# Altium schematic sheet power_board.SchDoc
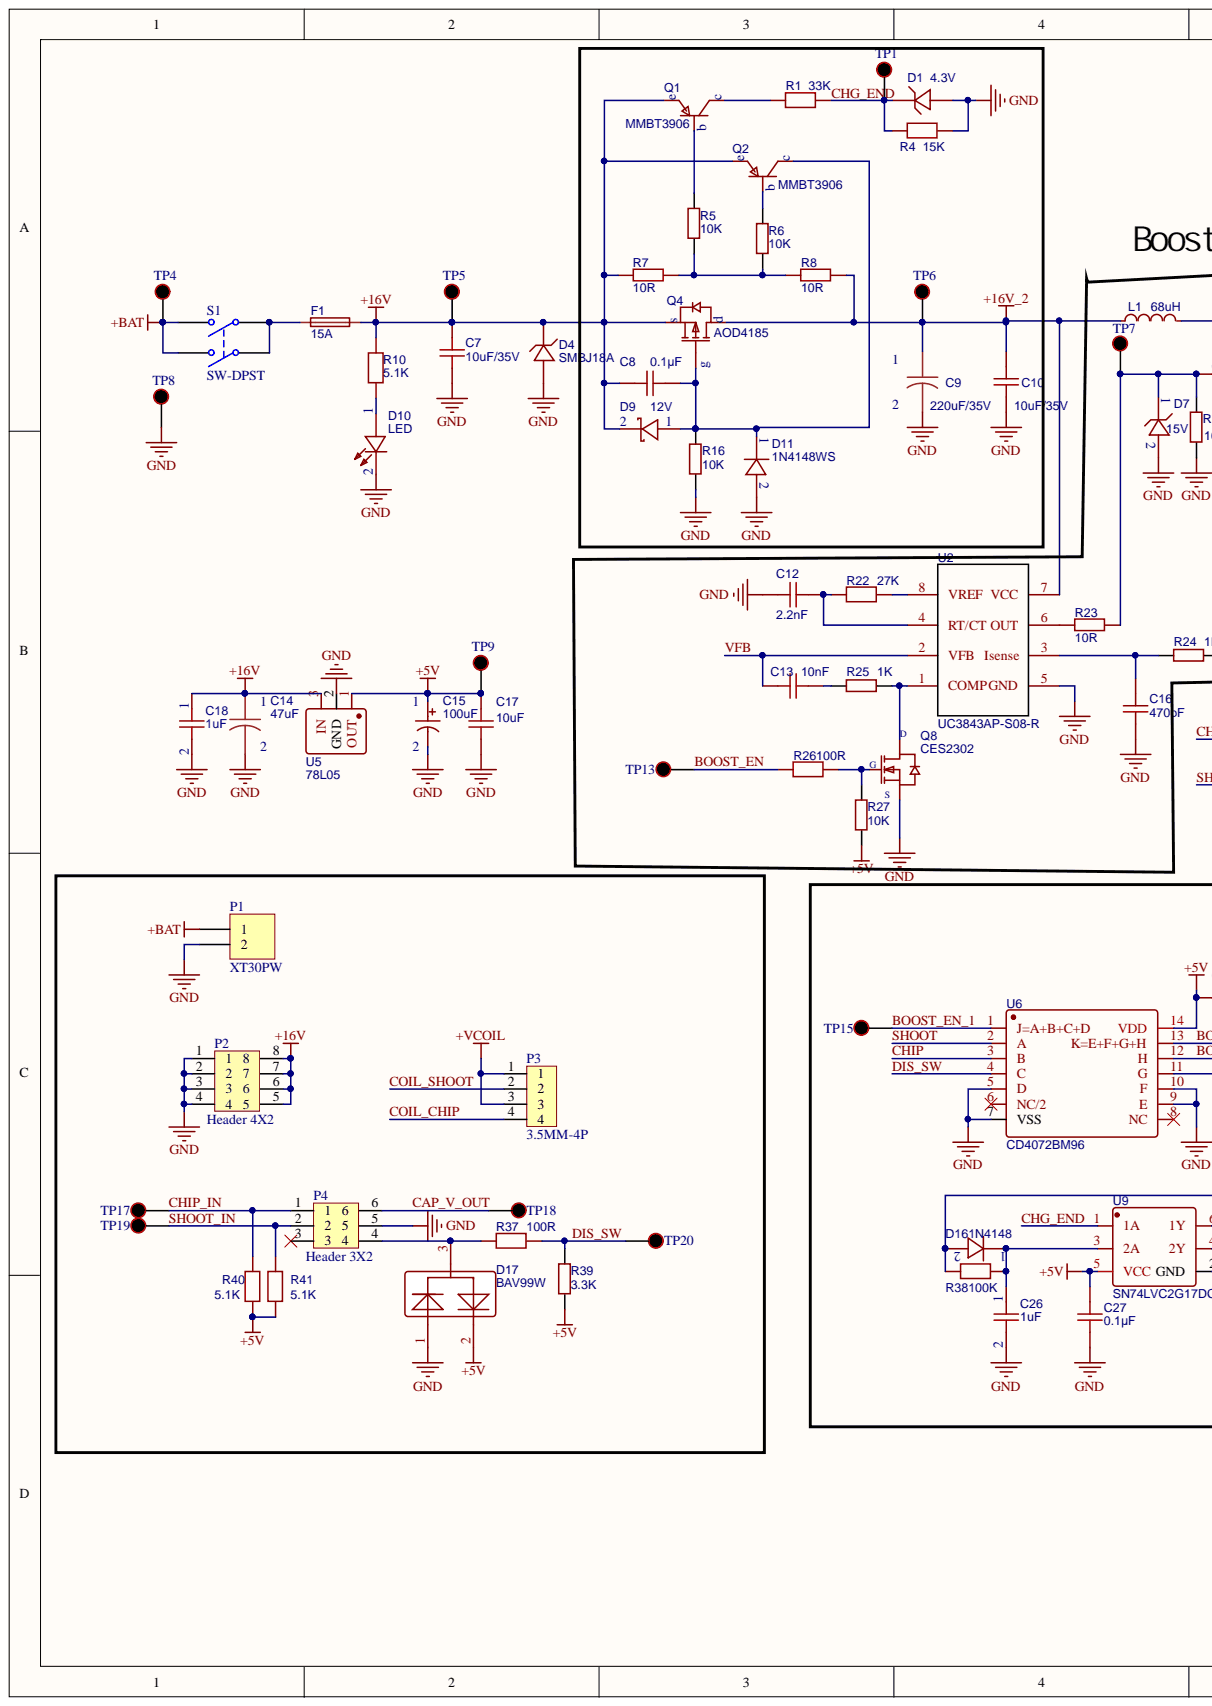
[diagram p0: power_board.SchDoc, left]
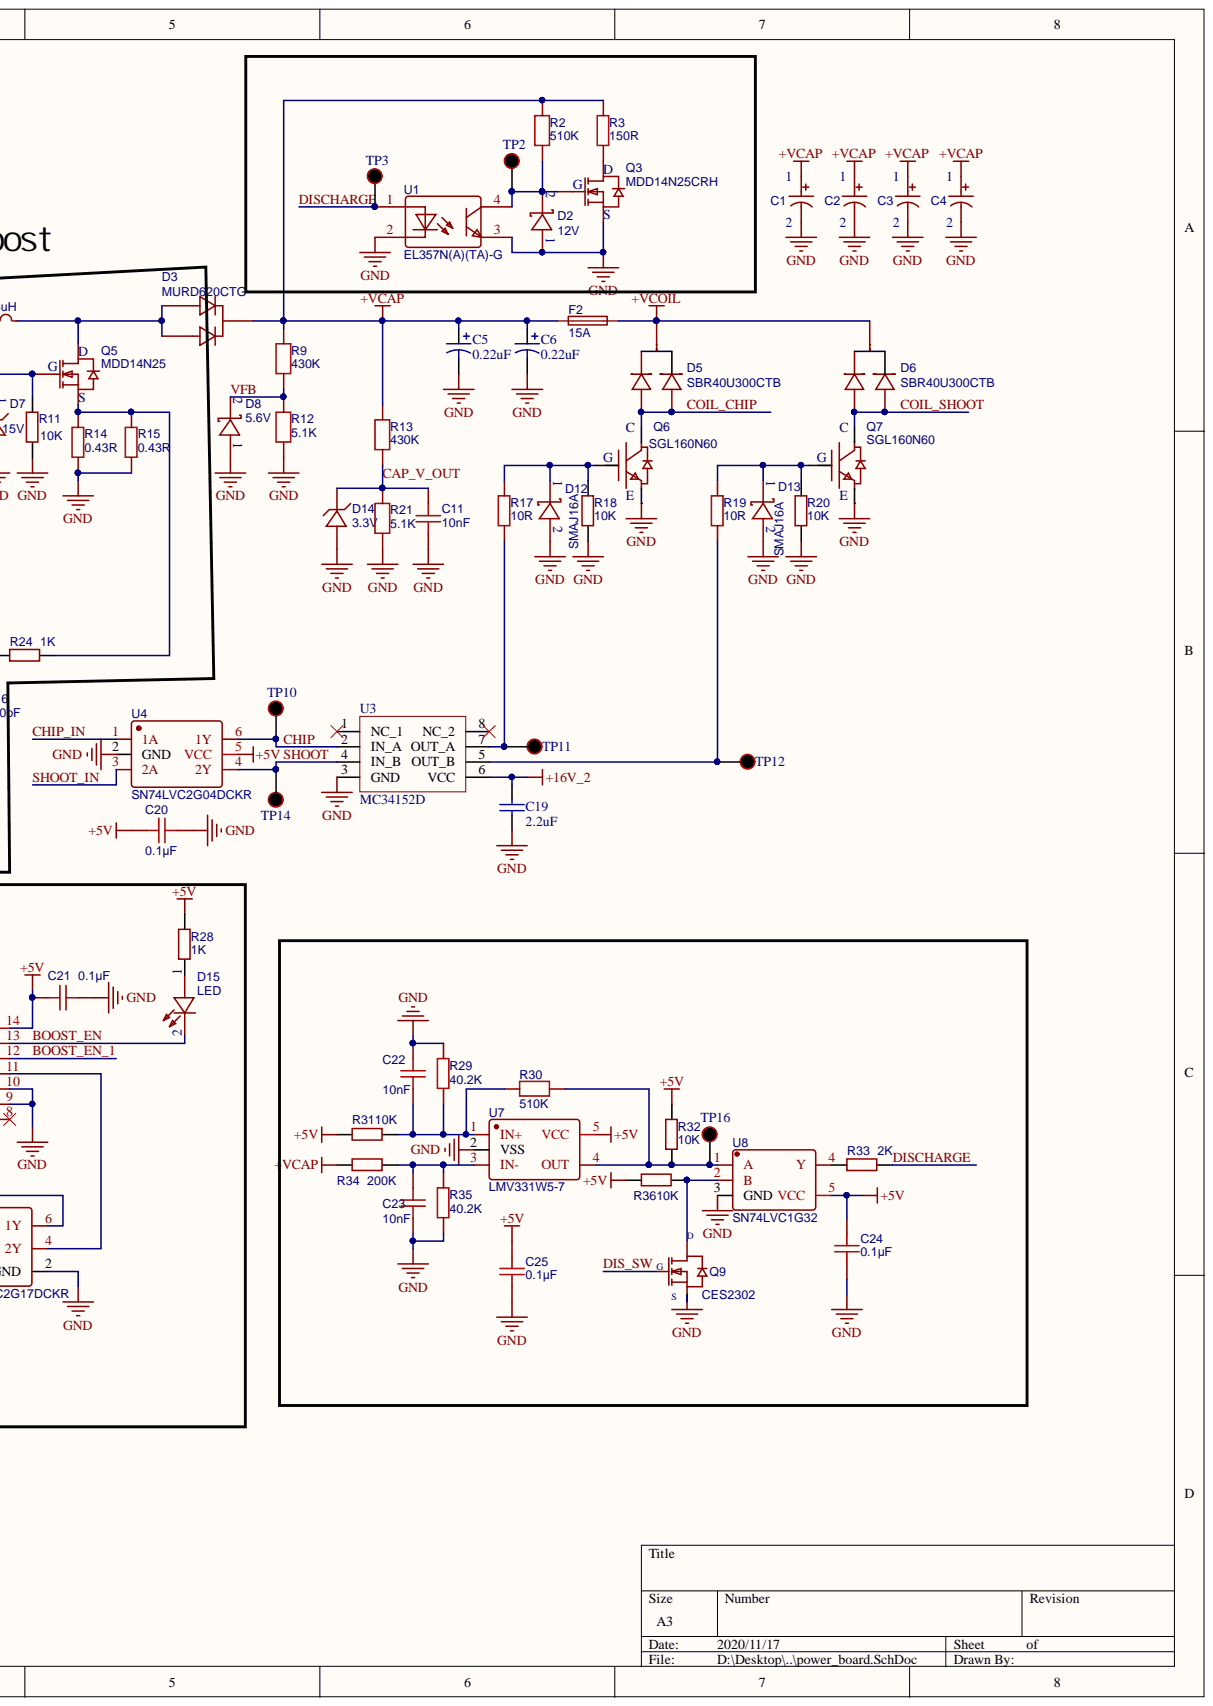
[diagram p1: power_board.SchDoc, right]

## Parts
C1  (no value)  [CAP_35X40]
C2  (no value)  [CAP_35X40]
C3  (no value)  [CAP_35X40]
C4  (no value)  [CAP_35X40]
C5  0.22uF  [1812]
C6  0.22uF  [1812]
C7  10uF/35V  [0805]
C8  0.1μF  [0805]
C9  220uF/35V  [CAP-SMD_BD8.0-L8.3-W8.3-FD]
C10  10uF/35V  [0805]
C11  10nF  [0805]
C12  2.2nF  [0805]
C13  10nF  [0805]
C14  47uF  [SMD,6.3x5.4mm-35V]
C15  100uF  [SMD,6.3x5.4mm]
C16  470pF  [0805]
C17  10uF  [0805]
C18  1uF  [0805]
C19  2.2uF  [0805]
C20  0.1μF  [0805]
C21  0.1μF  [0805]
C22  10nF  [0805]
C23  10nF  [0805]
C24  0.1μF  [0805]
C25  0.1μF  [0805]
C26  1uF  [0805]
C27  0.1μF  [0805]
D1  4.3V  [SOD-123_L2.8-W1.8-LS3.7-RD]
D2  12V  [SMA_L4.4-W2.8-LS5.4-R-RD]
D3  MURD620CTG  [TO-252-2_L6.6-W6.1-P4.57-LS9.9-BR-CW]
D4  SMBJ18A  spicePre: D  [SMB_L4.6-W3.6-LS5.3-RD]
D5  SBR40U300CTB  [D2PAK]
D6  SBR40U300CTB  [D2PAK]
D7  15V  [SOD-123_L2.8-W1.8-LS3.7-RD]
D8  5.6V  [SMA_L4.4-W2.8-LS5.4-R-RD]
D9  12V  [SMA_L4.4-W2.8-LS5.4-R-RD]
D10  LED  [LED_0805]
D11  1N4148WS  [SOD-323_L1.8-W1.3-LS2.5-RD]
D12  SMAJ16A  [SMA(DO-214AC)]
D13  SMAJ16A  [SMA(DO-214AC)]
D14  3.3V  [SOD-123]
D15  LED  [LED_0805]
D16  1N4148  [SOD-323_L1.8-W1.3-LS2.5-RD]
D17  BAV99W  [SOT-323(SC-70)]
F1  15A  [2410保险丝座]
F2  15A  [2410保险丝座]
L1  68uH  [IND-SMD_L13.8-W12.6]
P1  XT30PW  [XT30PW]
P2  Header 4X2  [paimu_2.54mm_2X4]
P3  3.5MM-4P  [KF250NH-3.5MM]
P4  Header 3X2  [paimu_2.54mm_2*3]
Q1  MMBT3906  [SOT-23-3_L2.9-W1.3-P1.90-LS2.4-BR]
Q2  MMBT3906  [SOT-23-3_L2.9-W1.3-P1.90-LS2.4-BR]
Q3  MDD14N25CRH  [TO-252-2_L6.6-W6.1-P4.57-LS9.9-BR-CW]
Q4  AOD4185  [TO-252-2_L6.6-W6.1-P4.57-LS9.9-BR-CW]
Q5  MDD14N25  [TO-252-2_L6.6-W6.1-P4.57-LS9.9-BR-CW]
Q6  SGL160N60  [TO-264]
Q7  SGL160N60  [TO-264]
Q8  CES2302  [CES2302/SOT-23(SOT-23-3)]
Q9  CES2302  [CES2302/SOT-23(SOT-23-3)]
R1  33K  [0805]
R2  510K  [1206]
R3  150R  [5W150R]
R4  15K  [0805]
R5  10K  [0805]
R6  10K  [0805]
R7  10R  [2512]
R8  10R  [2512]
R9  430K  [1206]
R10  5.1K  [0805]
R11  10K  [0805]
R12  5.1K  [0805]
R13  430K  [1206]
R14  0.43R  [2512]
R15  0.43R  [2512]
R16  10K  [0805]
R17  10R  [2512]
R18  10K  [0805]
R19  10R  [2512]
R20  10K  [0805]
R21  5.1K  [0805]
R22  27K  [0805]
R23  10R  [2512]
R24  1K  [0805]
R25  1K  [0805]
R26  100R  [0805]
R27  10K  [0805]
R28  1K  [0805]
R29  40.2K  [0805]
R30  510K  [0805]
R31  10K  [0805]  (value not drawn)
R32  10K  [0805]
R33  2K  [0805]
R34  200K  [0805]
R35  40.2K  [0805]
R36  10K  [0805]
R37  100R  [0805]
R38  100K  [0805]
R39  3.3K  [0805]
R40  5.1K  [0805]
R41  5.1K  [0805]
S1  SW-DPST  [KCD1-104B]
TP1  TestPoint  [TestPoint]  (value not drawn)
TP2  TestPoint  [TestPoint]  (value not drawn)
TP3  TestPoint  [TestPoint]  (value not drawn)
TP4  TestPoint  [TestPoint]  (value not drawn)
TP5  TestPoint  [TestPoint]  (value not drawn)
TP6  TestPoint  [TestPoint]  (value not drawn)
TP7  TestPoint  [TestPoint]  (value not drawn)
TP8  TestPoint  [TestPoint]  (value not drawn)
TP9  TestPoint  [TestPoint]  (value not drawn)
TP10  TestPoint  [TestPoint]  (value not drawn)
TP11  TestPoint  [TestPoint]  (value not drawn)
TP12  TestPoint  [TestPoint]  (value not drawn)
TP13  TestPoint  [TestPoint]  (value not drawn)
TP14  TestPoint  [TestPoint]  (value not drawn)
TP15  TestPoint  [TestPoint]  (value not drawn)
TP16  TestPoint  [TestPoint]  (value not drawn)
TP17  TestPoint  [TestPoint]  (value not drawn)
TP18  TestPoint  [TestPoint]  (value not drawn)
TP19  TestPoint  [TestPoint]  (value not drawn)
TP20  TestPoint  [TestPoint]  (value not drawn)
U1  EL357N(A)(TA)-G  [SOP-4_L4.4-W4.0-P2.54-LS7.0-TL - duplicate]
U2  UC3843AP-S08-R  [SOP-8_150MIL]
U3  MC34152D  [SOIC-8_150mil]
U4  SN74LVC2G04DCKR  [SC-70-6(SOT-363)]
U5  78L05  [SOT-89-3_L4.5-W2.5-P1.50-LS4.2-BR]
U6  CD4072BM96  [SOIC-14_L8.7-W3.9-P1.27-LS6.0-BL]
U7  LMV331W5-7  [SOT-25-5]
U8  SN74LVC1G32  [SC-70-5]
U9  SN74LVC2G17DCKR  [SC-70-6(SOT-363)]

## Nets
+5V: C15.1 C17.1 C20.2 C21.2 C24.2 C25.2 C27.2 D17.2 R27.1 R28.2 R31.2 R32.1 R36.1 R39.1 R40.1 R41.1 TP9.1 U4.5 U5.1 U6.14 U7.5 U8.5 U9.5
+16V: C7.1 C8.2 C14.1 C18.1 D4.1 D9.2 F1.2 P2.5 P2.6 P2.7 P2.8 Q1.2 Q2.2 Q4.3 R7.1 R10.2 TP5.1 U5.3
+16V_2: C9.1 C10.1 C19.2 L1.1 Q4.2 R8.2 TP6.1 U2.7 U3.6
+BAT: P1.1 S1.2 S1.4 TP4.1
+VCAP: C1.1 C2.1 C3.1 C4.1 C5.1 C6.1 D3.2 F2.1 R2.1 R3.2 R9.1 R13.2 R34.1
+VCOIL: D5.4 D6.4 F2.2 P3.1 P3.3
GND: C1.2 C2.2 C3.2 C4.2 C5.2 C6.2 C7.2 C9.2 C10.2 C11.2 C12.2 C14.2 C15.2 C16.1 C17.2 C18.2 C19.1 C20.1 C21.1 C22.2 C23.2 C24.1 C25.1 C26.2 C27.1 D1.2 D2.1 D4.2 D7.2 D8.1 D10.2 D11.2 D12.2 D13.2 D14.1 D17.1 P1.2 P2.1 P2.2 P2.3 P2.4 P4.5 Q3.3 Q6.3 Q7.3 Q8.2 Q9.2 R4.2 R11.1 R12.2 R14.1 R15.1 R16.1 R18.1 R20.1 R21.2 R29.2 R35.2 TP8.1 U1.2 U1.3 U2.5 U3.3 U4.2 U5.2 U6.5 U6.7 U6.9 U6.10 U7.2 U8.3 U9.2
BOOST_EN: D15.2 R26.1 TP13.1 U6.13
BOOST_EN_1: TP15.1 U6.1 U6.12
CAP_V_OUT: C11.1 D14.2 P4.6 R13.1 R21.1 TP18.1
CHG_END: D1.1 R1.2 R4.1 TP1.1 U9.1
CHIP: TP10.1 U3.2 U4.6 U6.3
CHIP_IN: P4.1 R40.2 TP17.1 U4.1
COIL_CHIP: D5.1 D5.3 P3.4 Q6.2
COIL_SHOOT: D6.1 D6.3 P3.2 Q7.2
DIS_SW: Q9.1 R37.2 R39.2 TP20.1 U6.4
DISCHARGE: R33.1 TP3.1 U1.1
SHOOT: TP14.1 U3.4 U4.4 U6.2
SHOOT_IN: P4.2 R41.2 TP19.1 U4.3
VFB: C13.2 D8.2 R9.2 R12.1 U2.2
NetC8_1: C8.1 D9.1 D11.1 Q2.3 Q4.1 R16.2
NetC12_1: C12.1 R22.1 U2.4
NetC13_1: C13.1 R25.1
NetC16_2: C16.2 R24.1 U2.3
NetC22_1: C22.1 R29.1 R30.1 R31.1 U7.1
NetC23_1: C23.1 R34.2 R35.1 U7.3
NetC26_1: C26.1 D16.1 R38.2 U9.3
NetD2_2: D2.2 Q3.1 R2.2 TP2.1 U1.4
NetD3_1: D3.1 D3.3 L1.2 Q5.2
NetD7_1: D7.1 Q5.1 R11.2 R23.2 TP7.1
NetD10_1: D10.1 R10.1
NetD12_1: D12.1 Q6.1 R17.2 R18.2
NetD13_1: D13.1 Q7.1 R19.2 R20.2
NetD15_1: D15.1 R28.1
NetD16_2: D16.2 R38.1 U9.6
NetD17_3: D17.3 P4.4 R37.1
NetF1_1: F1.1 S1.1 S1.3
NetQ1_1: Q1.1 R5.2
NetQ1_3: Q1.3 R1.1
NetQ2_1: Q2.1 R6.2
NetQ3_2: Q3.2 R3.1
NetQ5_3: Q5.3 R14.2 R15.2 R24.2
NetQ8_1: Q8.1 R26.2 R27.2
NetQ8_3: Q8.3 R25.2 U2.1
NetQ9_3: Q9.3 R36.2 U8.2
NetR5_1: R5.1 R6.1 R7.2 R8.1
NetR17_1: R17.1 TP11.1 U3.7
NetR19_1: R19.1 TP12.1 U3.5
NetR22_2: R22.2 U2.8
NetR23_1: R23.1 U2.6
NetR30_2: R30.2 R32.2 TP16.1 U7.4 U8.1
NetR33_2: R33.2 U8.4
NetU6_11: U6.11 U9.4

Not connected: P4 3; U3 1 8; U6 6 8

## Library data of the parts
C1-C4 = RVT1C101M0605: 100uF; BOM_Manufacturer: ROQANG; nameAlias: Capacitance; BOM_Manufacturer Part: RVT1C101M0605; BOM_Supplier: LCSC; BOM_Supplier Part: C72475; Component Kind: Standard; Library Name: power_board.SchLib; spicePre: C; spiceSymbolName: RVT1C101M0605-C72475
C5 C6 = Cap Pol3: Polarized Capacitor (Surface Mount); Component Kind: Standard; LatestRevisionDate: 29-May-2009; LatestRevisionNote: IPC-7351 Footprint Added.; Library Name: Miscellaneous Devices.IntLib; PackageDescription: Chip Capacitor; PackageReference: C0805; Published: 8-Jun-2000; Signal Integrity: Cap Pol; Simulation: CAP; Value: 0.22uF; Publisher: Altium Limited
C7 C10 = GMK316BJ106KL-T: 10uF; BOM_Manufacturer: Taiyo Yuden; Contributor: LCSC; nameAlias: Capacitance; BOM_Manufacturer Part: GMK316BJ106KL-T; BOM_Supplier: LCSC; BOM_Supplier Part: C92797; Component Kind: Standard; Library Name: power_board.SchLib; spicePre: C; spiceSymbolName: GMK316BJ106KL-T
C8 C20 C21 C24 C25 C27 = CT41G-0805-2X1-50V-0.1μF-M(N): 100nF; BOM_Manufacturer: TORCH; Contributor: LCSC; nameAlias: Capacitance; BOM_Manufacturer Part: CT41G-0805-2X1-50V-0.1μF-M(N); BOM_Supplier: LCSC; BOM_Supplier Part: C138340; Component Kind: Standard; Library Name: power_board.SchLib; spicePre: C; spiceSymbolName: CT41G-0805-2X1-50V-0.1μF-M(N)
C9 = VD1V221MF105000CE0: 220uF; BOM_Manufacturer: Changzhou Huawei Elec; Contributor: LCSC; nameAlias: Capacitance; BOM_Manufacturer Part: VD1V221MF105000CE0; BOM_Supplier: LCSC; BOM_Supplier Part: C189259; Component Kind: Standard; Library Name: power_board.SchLib; spicePre: C; spiceSymbolName: VD1V221MF105000CE0
C11 C13 C22 C23 = C1206X103K631T: BOM_Manufacturer: Holy Stone Enterprise; Contributor: LCSC; nameAlias: Capacitance; BOM_Manufacturer Part: C1206X103K631T; BOM_Supplier: LCSC; BOM_Supplier Part: C337974; Component Kind: Standard; Description: 10nF; Library Name: power_board.SchLib; spicePre: C; spiceSymbolName: C1206X103K631T
C12 = CS0603KRX7R9BB222: BOM_Manufacturer: YAGEO; Contributor: LCSC; nameAlias: Capacitance; BOM_Manufacturer Part: CS0603KRX7R9BB222; BOM_Supplier: LCSC; BOM_Supplier Part: C309517; Component Kind: Standard; Description: 2.2nF; Library Name: power_board.SchLib; spicePre: C; spiceSymbolName: CS0603KRX7R9BB222
C14 = VT1V470M0605: BOM_Manufacturer: ROQANG; nameAlias: Capacitance; BOM_Manufacturer Part: VT1V470M0605; BOM_Supplier: LCSC; BOM_Supplier Part: C90276; Component Kind: Standard; Description: 47uF; Library Name: power_board.SchLib; spicePre: C; spiceSymbolName: VT1V470M0605
C15 = RVT1C101M0605: BOM_Manufacturer: ROQANG; nameAlias: Capacitance; BOM_Manufacturer Part: RVT1C101M0605; BOM_Supplier: LCSC; BOM_Supplier Part: C72475; Component Kind: Standard; Description: 100uF; Library Name: power_board.SchLib; spicePre: C; spiceSymbolName: RVT1C101M0605-C72475
C16 = CL21C471JCCNNNC: 470uF; BOM_Manufacturer: Samsung Electro-Mechanics; Contributor: LCSC; nameAlias: Capacitance; BOM_Manufacturer Part: CL21C471JCCNNNC; BOM_Supplier: LCSC; BOM_Supplier Part: C318726; Component Kind: Standard; Library Name: power_board.SchLib; spicePre: C; spiceSymbolName: CL21C471JCCNNNC
C17 = GMK316BJ106KL-T: BOM_Manufacturer: Taiyo Yuden; Contributor: LCSC; nameAlias: Capacitance; BOM_Manufacturer Part: GMK316BJ106KL-T; BOM_Supplier: LCSC; BOM_Supplier Part: C92797; Component Kind: Standard; Description: 10uF; Library Name: power_board.SchLib; spicePre: C; spiceSymbolName: GMK316BJ106KL-T
C18 C26 = UMK212BJ105KG-T: BOM_Manufacturer: Taiyo Yuden; Contributor: LCSC; nameAlias: Capacitance; BOM_Manufacturer Part: UMK212BJ105KG-T; BOM_Supplier: LCSC; BOM_Supplier Part: C319195; Component Kind: Standard; Description: 1uF; Library Name: power_board.SchLib; spicePre: C; spiceSymbolName: UMK212BJ105KG-T
C19 = Cap Semi: Capacitor (Semiconductor SIM Model); Component Kind: Standard; LatestRevisionDate: 29-May-2009; LatestRevisionNote: IPC-7351 Footprint Added.; Library Name: Miscellaneous Devices.IntLib; PackageDescription: Chip Capacitor; PackageReference: C1206; Published: 8-Jun-2000; Signal Integrity: Cap Semi; Simulation: CAP; Publisher: Altium Limited
D1 = BZT52C4V3_C213114: BOM_Manufacturer: Formosa Microsemi; Contributor: LCSC; BOM_Manufacturer Part: BZT52C4V3; BOM_Supplier: LCSC; BOM_Supplier Part: C213114; Component Kind: Standard; Library Name: power_board.SchLib; spicePre: D; spiceSymbolName: BZT52C4V3_C213114
D2 D8 D9 = 1SMA4742: BOM_Manufacturer: Sangdest Microelectronicstronic (Nanjing); Contributor: LCSC; BOM_Manufacturer Part: 1SMA4742; BOM_Supplier: LCSC; BOM_Supplier Part: C260227; Component Kind: Standard; Library Name: power_board.SchLib; spicePre: D; spiceSymbolName: 1SMA4742
D3 = MURD620CTG: BOM_Manufacturer: ON; Contributor: LCSC; BOM_Manufacturer Part: MURD620CTG; BOM_Supplier: LCSC; BOM_Supplier Part: C115119; Component Kind: Standard; Library Name: power_board.SchLib; spicePre: D; spiceSymbolName: MURD620CTG
D4 = SMBJ18A_C152104: BOM_Manufacturer: Bourne Semicon (Shenzhen); Contributor: LCSC; SMT Type: Extend; BOM_LCSC Assembly: Yes; BOM_Manufacturer Part: SMBJ18A; BOM_Supplier: LCSC; BOM_Supplier Part: C152104; Component Kind: Standard; Library Name: power_board.SchLib; spiceSymbolName: SMBJ18A_C152104
D5 D6 = MBRB20200CT: BOM_Manufacturer: Sangdest Microelectronicstronic (Nanjing); Contributor: LCSC; BOM_Manufacturer Part: MBRB20200CT; BOM_Supplier: LCSC; BOM_Supplier Part: C260294; Component Kind: Standard; Library Name: power_board.SchLib; spicePre: D; spiceSymbolName: MBRB20200CT
D7 = DZL4744: BOM_Manufacturer: LGE; Contributor: LCSC; BOM_Manufacturer Part: DZL4744; BOM_Supplier: LCSC; BOM_Supplier Part: C362313; Component Kind: Standard; Library Name: power_board.SchLib; spicePre: D; spiceSymbolName: DZL4744
D10 D15 = BL-HZ337A-L6-TRB: BOM_Manufacturer: Bright LED Elec; Contributor: LCSC; BOM_Manufacturer Part: BL-HZ337A-L6-TRB; BOM_Supplier: LCSC; BOM_Supplier Part: C189305; Component Kind: Standard; Library Name: power_board.SchLib; spicePre: L; spiceSymbolName: BL-HZ337A-L6-TRB
D11 D16 = 1N4148WS_C110221: BOM_Manufacturer: SK; Contributor: LCSC; BOM_Manufacturer Part: 1N4148WS; BOM_Supplier: LCSC; BOM_Supplier Part: C110221; Component Kind: Standard; Library Name: power_board.SchLib; spicePre: D; spiceSymbolName: 1N4148WS_C110221
D12 D13 = SMAJ16A: BOM_Manufacturer: FMS; Contributor: LCSC; SMT Type: Extend; BOM_LCSC Assembly: Yes; BOM_Manufacturer Part: SMAJ16A; BOM_Supplier: LCSC; BOM_Supplier Part: C143121; Component Kind: Standard; Library Name: power_board.SchLib; spicePre: D; spiceSymbolName: SMAJ16A
D14 = MM1Z3B3: BOM_Manufacturer: SEMTECH; BOM_Manufacturer Part: MM1Z3B3; BOM_Supplier: LCSC; BOM_Supplier Part: C108453; Component Kind: Standard; Library Name: power_board.SchLib; spicePre: D; spiceSymbolName: MM1Z3B3
D17 = BAV99W: BOM_Manufacturer: CJ; SMT Type: Extend; BOM_LCSC Assembly: Yes; BOM_Manufacturer Part: BAV99W; BOM_Supplier: LCSC; BOM_Supplier Part: C26216; Component Kind: Standard; Library Name: power_board.SchLib; spicePre: D; spiceSymbolName: BAV99W
F1 F2 = SAF15.0A: BOM_Manufacturer: TLC Electronic; Contributor: LCSC; BOM_Manufacturer Part: SAF15.0A; BOM_Supplier: LCSC; BOM_Supplier Part: C312000; Component Kind: Standard; Library Name: power_board.SchLib; spicePre: F; spiceSymbolName: SAF15.0A
L1 = SMMS1360-680M: BOM_Manufacturer: ShunXiang Connaught Elec; Contributor: LCSC; nameAlias: Inductance; BOM_Manufacturer Part: SMMS1360-680M; BOM_Supplier: LCSC; BOM_Supplier Part: C149532; Component Kind: Standard; Description: 68uH; Library Name: power_board.SchLib; spicePre: L; spiceSymbolName: SMMS1360-680M
P1 = Header 2: Header, 2-Pin; Component Kind: Standard; LatestRevisionDate: 17-Jul-2002; LatestRevisionNote: Re-released for DXP Platform.; Library Name: Miscellaneous Connectors.IntLib; Signal Integrity: Connector; Publisher: Altium Limited
P2 = Header2X4: Component Kind: Standard; Library Name: power_board.SchLib
P4 = Header2X3: Component Kind: Standard; Library Name: power_board.SchLib
Q1 Q2 = MMBT3906_C2143: BOM_Manufacturer: CJ; SMT Type: Extend; BOM_LCSC Assembly: Yes; BOM_Manufacturer Part: MMBT3906; BOM_Supplier: LCSC; BOM_Supplier Part: C2143; Component Kind: Standard; image: //image.lceda.cn/szlcsc/C2143.jpg; Library Name: power_board.SchLib; spicePre: Q; spiceSymbolName: MMBT3906_C2143
Q3 Q5 = MDD14N25CRH: BOM_Manufacturer: MagnaChip; SMT Type: Extend; BOM_LCSC Assembly: Yes; BOM_Manufacturer Part: MDD14N25CRH; BOM_Supplier: LCSC; BOM_Supplier Part: C108997; Component Kind: Standard; Library Name: power_board.SchLib; spicePre: Q; spiceSymbolName: MDD14N25CRH
Q4 = AOD4185: BOM_Manufacturer: AOS; SMT Type: Extend; BOM_LCSC Assembly: Yes; BOM_Manufacturer Part: AOD4185; BOM_Supplier: LCSC; BOM_Supplier Part: C77993; Component Kind: Standard; Library Name: power_board.SchLib; spicePre: Q; spiceSymbolName: AOD4185
Q6 Q7 = SGL160N60UFDTU_1: IGBT; BOM_Manufacturer: FAIRCHILD; BOM_Manufacturer Part: SGL160N60UFDTU; BOM_Supplier: LCSC; BOM_Supplier Part: C11757; Component Kind: Standard; image: //image.lceda.cn/szlcsc/C11757.jpg; Library Name: power_board.SchLib; spicePre: Q; spiceSymbolName: SGL160N60UFDTU
Q8 Q9 = CES2302: BOM_Manufacturer: Chino-Excel Tech; Contributor: LCSC; SMT Type: Extend; BOM_LCSC Assembly: Yes; BOM_Manufacturer Part: CES2302; BOM_Supplier: LCSC; BOM_Supplier Part: C154288; Component Kind: Standard; Library Name: power_board.SchLib; spicePre: Q; spiceSymbolName: CES2302
R1 = AC1206JR-0733KL: BOM_Manufacturer: YAGEO; Contributor: LCSC; nameAlias: Resistance (Ohms); BOM_Manufacturer Part: AC1206JR-0733KL; BOM_Supplier: LCSC; BOM_Supplier Part: C229931; Component Kind: Standard; Description: 33K; Library Name: power_board.SchLib; spicePre: R; spiceSymbolName: AC1206JR-0733KL
R2 R30 = AC1206JR-07510KL: BOM_Manufacturer: YAGEO; Contributor: LCSC; nameAlias: Resistance (Ohms); BOM_Manufacturer Part: AC1206JR-07510KL; BOM_Supplier: LCSC; BOM_Supplier Part: C229958; Component Kind: Standard; Description: 510K; Library Name: power_board.SchLib; spicePre: R; spiceSymbolName: AC1206JR-07510KL
R3 = CR-M15W150ΩJ: BOM_Manufacturer: Chian Chia Elec; Contributor: LCSC; nameAlias: Resistance (Ohms); BOM_Manufacturer Part: CR-M15W150ΩJ; BOM_Supplier: LCSC; BOM_Supplier Part: C216412; Component Kind: Standard; Description: 150R; Library Name: power_board.SchLib; spicePre: R; spiceSymbolName: CR-M15W150ΩJ
R4 = AC1206JR-0715KL: BOM_Manufacturer: YAGEO; Contributor: LCSC; nameAlias: Resistance (Ohms); BOM_Manufacturer Part: AC1206JR-0715KL; BOM_Supplier: LCSC; BOM_Supplier Part: C229881; Component Kind: Standard; Description: 15K; Library Name: power_board.SchLib; spicePre: R; spiceSymbolName: AC1206JR-0715KL
R5 R6 R11 R16 R18 R20 R27 R31 R32 R36 = RS-06K1002FT: BOM_Manufacturer: FH; nameAlias: Resistance (Ohms); BOM_Manufacturer Part: RS-06K1002FT; BOM_Supplier: LCSC; BOM_Supplier Part: C140407; Component Kind: Standard; Description: 10K; Library Name: power_board.SchLib; spicePre: R; spiceSymbolName: RS-06K1002FT
R7 R8 R17 R19 R23 = RC2512JK-7W10RL: 10; BOM_Manufacturer: YAGEO; Contributor: LCSC; nameAlias: Resistance (Ohms); BOM_Manufacturer Part: RC2512JK-7W10RL; BOM_Supplier: LCSC; BOM_Supplier Part: C173291; Component Kind: Standard; Library Name: power_board.SchLib; spicePre: R; spiceSymbolName: RC2512JK-7W10RL
R9 R13 = AC1206JR-0727KL: 27K; BOM_Manufacturer: YAGEO; Contributor: LCSC; nameAlias: Resistance (Ohms); BOM_Manufacturer Part: AC1206JR-0727KL; BOM_Supplier: LCSC; BOM_Supplier Part: C229915; Component Kind: Standard; Library Name: power_board.SchLib; spicePre: R; spiceSymbolName: AC1206JR-0727KL
R10 R12 R21 R40 R41 = WR06X512 JTL: BOM_Manufacturer: Walsin Tech Corp; Contributor: LCSC; nameAlias: Resistance (Ohms); BOM_Manufacturer Part: WR06X512 JTL; BOM_Supplier: LCSC; BOM_Supplier Part: C384212; Component Kind: Standard; Description: 5.1K; Library Name: power_board.SchLib; spicePre: R; spiceSymbolName: WR06X512 JTL
R14 R15 = CR2512JR430E04R: 0.43; BOM_Manufacturer: Ever Ohms Tech; BOM_Manufacturer Part: CR2512J0R43E04; Contributor: LCSC; nameAlias: Resistance (Ohms); BOM_Supplier: LCSC; BOM_Supplier Part: C176090; Component Kind: Standard; Library Name: power_board.SchLib; spicePre: R; spiceSymbolName: CR2512J0R43E04
R22 = AC1206JR-0727KL: BOM_Manufacturer: YAGEO; Contributor: LCSC; nameAlias: Resistance (Ohms); BOM_Manufacturer Part: AC1206JR-0727KL; BOM_Supplier: LCSC; BOM_Supplier Part: C229915; Component Kind: Standard; Description: 27K; Library Name: power_board.SchLib; spicePre: R; spiceSymbolName: AC1206JR-0727KL
R24 R25 R28 = 0805W8J0102T5E: BOM_Manufacturer: UniOhm; nameAlias: Resistance (Ohms); BOM_Manufacturer Part: 0805W8J0102T5E; BOM_Supplier: LCSC; BOM_Supplier Part: C25623; Component Kind: Standard; Description: 1K; Library Name: power_board.SchLib; spicePre: R; spiceSymbolName: 0805W8J0102T5E
R26 R37 = AC0402DR-0736KL: 36K; BOM_Manufacturer: YAGEO; Contributor: LCSC; nameAlias: Resistance (Ohms); BOM_Manufacturer Part: AC0402DR-0736KL; BOM_Supplier: LCSC; BOM_Supplier Part: C226709; Component Kind: Standard; Library Name: power_board.SchLib; spicePre: R; spiceSymbolName: AC0402DR-0736KL
R29 R35 = RMC060340.2K1%N: BOM_Manufacturer: Tyohm; Contributor: LCSC; nameAlias: Resistance (Ohms); BOM_Manufacturer Part: RMC060340.2K1%N; BOM_Supplier: LCSC; BOM_Supplier Part: C325594; Component Kind: Standard; Description: 40.2K; Library Name: power_board.SchLib; spicePre: R; spiceSymbolName: RMC060340.2K1%N
R33 R34 = 0603WAF3301T5E: 3.3K; BOM_Manufacturer: UniOhm; nameAlias: Resistance (Ohms); SMT Type: Basic; BOM_LCSC Assembly: Yes; BOM_Manufacturer Part: 0603WAF3301T5E; BOM_Supplier: LCSC; BOM_Supplier Part: C22978; Component Kind: Standard; Library Name: power_board.SchLib; spicePre: R; spiceSymbolName: 0603WAF3301T5E
R38 = AC0402DR-07100KL: BOM_Manufacturer: YAGEO; Contributor: LCSC; nameAlias: Resistance (Ohms); BOM_Manufacturer Part: AC0402DR-07100KL; BOM_Supplier: LCSC; BOM_Supplier Part: C226676; Component Kind: Standard; Description: 100K; Library Name: power_board.SchLib; spicePre: R; spiceSymbolName: AC0402DR-07100KL
R39 = 0603WAF3301T5E: BOM_Manufacturer: UniOhm; nameAlias: Resistance (Ohms); SMT Type: Basic; BOM_LCSC Assembly: Yes; BOM_Manufacturer Part: 0603WAF3301T5E; BOM_Supplier: LCSC; BOM_Supplier Part: C22978; Component Kind: Standard; Description: 3.3K; Library Name: power_board.SchLib; spicePre: R; spiceSymbolName: 0603WAF3301T5E
S1 = SW-DPST: Double-Pole, Single-Throw Switch; Component Kind: Standard; LatestRevisionDate: 17-Jul-2002; LatestRevisionNote: Re-released for DXP Platform.; Library Name: Miscellaneous Devices.IntLib; PackageDescription: Switch; 4 Leads; PackageReference: DPST-4; Published: 24-Mar-1999; Publisher: Altium Limited
U1 = EL357N(A)(TA)-G: BOM_Manufacturer: EVERLIGHT; Contributor: LCSC; SMT Type: Extend; BOM_LCSC Assembly: Yes; BOM_Manufacturer Part: EL357N(A)(TA)-G; BOM_Supplier: LCSC; BOM_Supplier Part: C142286; Component Kind: Standard; Library Name: power_board.SchLib; spicePre: U; spiceSymbolName: EL357N(A)(TA)-G
U2 = UC3843AP-S08-R: BOM_Manufacturer: UTC; SMT Type: Extend; BOM_LCSC Assembly: Yes; BOM_Manufacturer Part: UC3843AP-S08-R; BOM_Supplier: LCSC; BOM_Supplier Part: C71120; Component Kind: Standard; image: //image.lceda.cn/szlcsc/C71120.jpg; Library Name: power_board.SchLib; spicePre: U; spiceSymbolName: UC3843AP-S08-R
U4 = SN74LVC2G04DCKR: BOM_Manufacturer: TI; SMT Type: Extend; BOM_LCSC Assembly: Yes; BOM_Manufacturer Part: SN74LVC2G04DCKR; BOM_Supplier: LCSC; BOM_Supplier Part: C105251; Component Kind: Standard; Library Name: power_board.SchLib; spicePre: U; spiceSymbolName: SN74LVC2G04DCKR
U5 = 78L05_C402226: BOM_Manufacturer: LGE; Contributor: LCSC; BOM_Manufacturer Part: 78L05; BOM_Supplier: LCSC; BOM_Supplier Part: C402226; Component Kind: Standard; Library Name: power_board.SchLib; spicePre: U; spiceSymbolName: 78L05_C402226
U6 = CD4072BM96: BOM_Manufacturer: Texas Instruments; Contributor: LCSC; SMT Type: Extend; BOM_LCSC Assembly: Yes; BOM_Manufacturer Part: CD4072BM96; BOM_Supplier: LCSC; BOM_Supplier Part: C68527; Component Kind: Standard; Library Name: power_board.SchLib; spicePre: U; spiceSymbolName: CD4072BM96
U7 = LMV331W5-7: BOM_Manufacturer: Diodes Incorporated; Contributor: LCSC; BOM_Manufacturer Part: LMV331W5-7; BOM_Supplier: LCSC; BOM_Supplier Part: C107643; Component Kind: Standard; Library Name: power_board.SchLib; spicePre: U; spiceSymbolName: LMV331W5-7
U8 = SN74LVC1G32DCKR: BOM_Manufacturer: TI; SMT Type: Extend; BOM_LCSC Assembly: Yes; BOM_Manufacturer Part: SN74LVC1G32DCKR; BOM_Supplier: LCSC; BOM_Supplier Part: C7840; Component Kind: Standard; image: //image.lceda.cn/szlcsc/C7840.jpg; Library Name: power_board.SchLib; spicePre: X; spiceSymbolName: SN74LVC1G32DCKR
U9 = SN74LVC2G17DCKR: BOM_Manufacturer: TI; Contributor: LCSC; SMT Type: Extend; BOM_LCSC Assembly: Yes; BOM_Manufacturer Part: SN74LVC2G17DCKR; BOM_Supplier: LCSC; BOM_Supplier Part: C50714; Component Kind: Standard; Library Name: power_board.SchLib; spicePre: U; spiceSymbolName: SN74LVC2G17DCKR
P3 = 3.5mm-4P: Component Kind: Standard; Library Name: power_board.SchLib
TP1-TP20 = TestPoint: Component Kind: Standard; Library Name: power_board.SchLib
U3 = MC34152D: Component Kind: Standard; Library Name: power_board.SchLib
